# Altium schematic sheet Sheet1.SchDoc
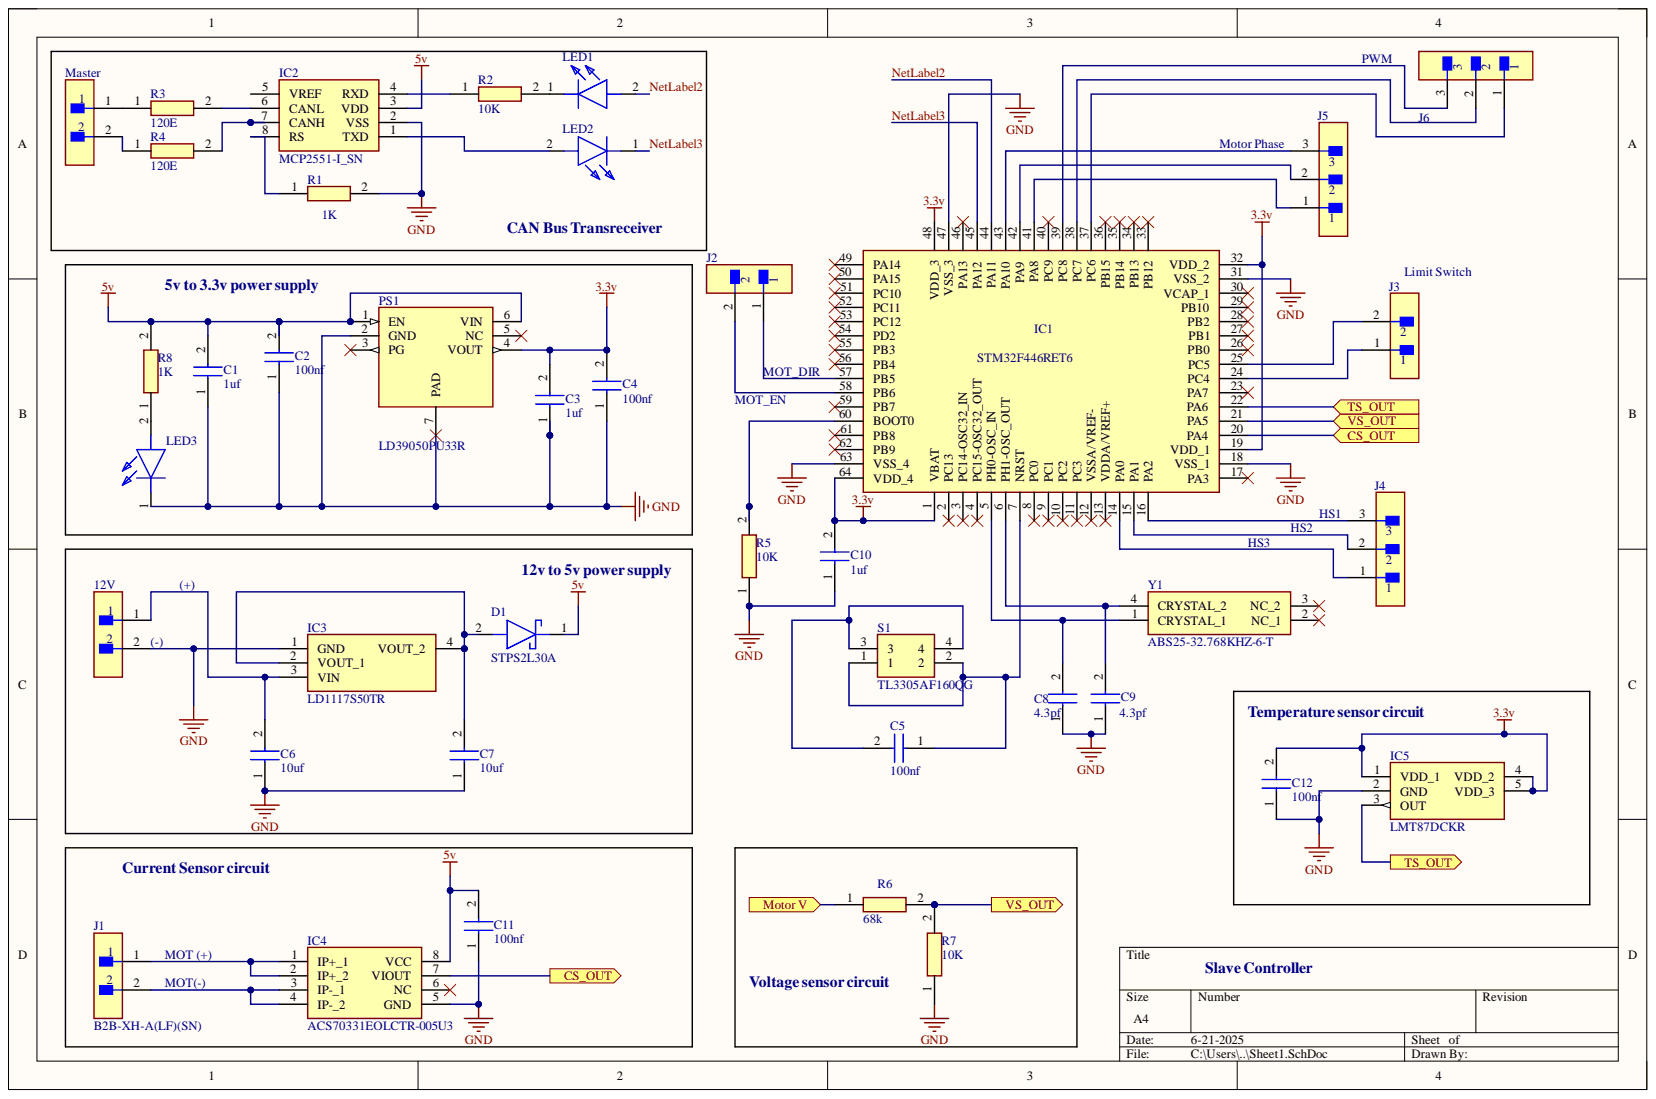
[diagram p0: Sheet1.SchDoc]

## Parts
12V  B2B-XH-A(LF)(SN)  [FP-B2B-XH-A_LF_SN-MFG]
C1  1uf  [CAPC1005X55N]
C2  100nf  [CAPC2012X94N]
C3  1uf  [CAPC1005X55N]
C4  100nf  [CAPC2012X94N]
C5  100nf  [CAPC2012X94N]
C6  10uf  [MBASx31x]
C7  10uf  [MBASx31x]
C8  4.3pf  [GRM033D70J224ME01D]
C9  4.3pf  [GRM033D70J224ME01D]
C10  1uf  [CAPC1005X55N]
C11  100nf  [CAPC2012X94N]
C12  100nf  [CAPC2012X94N]
D1  STPS2L30A  [DIOM5126X265N]
IC1  STM32F446RET6  [QFP50P1200X1200X160-64N]
IC2  MCP2551-I_SN  [SOIC127P600X175-8N]
IC3  LD1117S50TR  [SOT230P700X190-4N]
IC4  ACS70331EOLCTR-005U3  [SOIC127P600X175-8N]  (net tie)
IC5  LMT87DCKR  [SOT65P210X110-5N]
J1  B2B-XH-A(LF)(SN)  [FP-B2B-XH-A_LF_SN-MFG]
J2  B2B-XH-A(LF)(SN)  [FP-B2B-XH-A_LF_SN-MFG]
J3  B2B-XH-A(LF)(SN)  [FP-B2B-XH-A_LF_SN-MFG]
J4  B3B-XH-A(LF)(SN)  [FP-B3B-XH-A_LF_SN-MFG]  (value not drawn)
J5  B3B-XH-A(LF)(SN)  [FP-B3B-XH-A_LF_SN-MFG]  (value not drawn)
J6  B3B-XH-A(LF)(SN)  [FP-B3B-XH-A_LF_SN-MFG]  (value not drawn)
LED1  (no value)  [KSEELP4122P1R258A8J8020R18]
LED2  (no value)  [KSEELP4122P1R258A8J8020R18]
LED3  (no value)  [KSEELP4122P1R258A8J8020R18]
Master  Master  [FP-B2B-XH-A_LF_SN-MFG]
PS1  LD39050PU33R  [SON95P300X300X100-7N-D]
R1  1K  [ERA2AED122X]
R2  10K  [RESC2012X65N]
R3  120E  [RESC1005X40N]
R4  120E  [RESC1005X40N]
R5  10K  [RESC2012X65N]
R6  68k  [RESC1005X37N]
R7  10K  [RESC2012X65N]
R8  1K  [ERA2AED122X]
S1  TL3305AF160QG  [TL3305AF160QG]
Y1  ABS25-32.768KHZ-6-T  [ABS2532768KHZT]

## Ports
CS_OUT, Motor V, TS_OUT, VS_OUT

## Nets
3.3v: C3.2 C4.2 C10.2 C12.2 IC1.1(VBAT) IC1.19(VDD_1) IC1.32(VDD_2) IC1.48(VDD_3) IC1.64(VDD_4) IC5.1(VDD_1) IC5.4(VDD_2) IC5.5(VDD_3) PS1.4(VOUT)
5v: C1.2 C2.2 C11.2 D1.1 IC2.3(VDD) IC4.8(VCC) PS1.1(EN) PS1.6(VIN) R8.2
GND: 12V.2 C1.1 C2.1 C3.1 C4.1 C6.1 C7.1 C8.1 C9.1 C10.1 C11.1 C12.1 IC1.18(VSS_1) IC1.31(VSS_2) IC1.47(VSS_3) IC1.63(VSS_4) IC2.2(VSS) IC3.1(GND) IC4.5(GND) IC5.2(GND) LED3.1 PS1.2(GND) PS1.7(PAD) R1.2 R5.1 R7.1
CS_OUT: IC1.20(PA4) IC4.7(VIOUT) ; port CS_OUT
Motor V: R6.1 ; port Motor V
NetLabel2: IC1.44(PA11) LED1.2
NetLabel3: IC1.45(PA12) LED2.1
TS_OUT: IC1.22(PA6) IC5.3(OUT) ; port TS_OUT
VS_OUT: IC1.21(PA5) R6.2 R7.2 ; port VS_OUT
Net12V_1: 12V.1 C6.2 IC3.3(VIN)
NetC5_1: C5.1 IC1.7(NRST) S1.1 S1.2
NetC5_2: C5.2 S1.3 S1.4
NetC7_2: C7.2 D1.2 IC3.2(VOUT_1) IC3.4(VOUT_2)
NetC8_2: C8.2 IC1.5(PH0-OSC_IN) Y1.1(CRYSTAL_1)
NetC9_2: C9.2 IC1.6(PH1-OSC_OUT) Y1.4(CRYSTAL_2)
NetIC1_14: IC1.14(PA0) J4.1
NetIC1_15: IC1.15(PA1) J4.2
NetIC1_16: IC1.16(PA2) J4.3
NetIC1_24: IC1.24(PC4) J3.1
NetIC1_25: IC1.25(PC5) J3.2
NetIC1_37: IC1.37(PC6) J6.1
NetIC1_38: IC1.38(PC7) J6.2
NetIC1_39: IC1.39(PC8) J6.3
NetIC1_41: IC1.41(PA8) J5.1
NetIC1_42: IC1.42(PA9) J5.2
NetIC1_43: IC1.43(PA10) J5.3
NetIC1_57: IC1.57(PB5) J2.1
NetIC1_58: IC1.58(PB6) J2.2
NetIC1_60: IC1.60(BOOT0) R5.2
NetIC2_1: IC2.1(TXD) LED2.2
NetIC2_4: IC2.4(RXD) R2.1
NetIC2_6: IC2.6(CANL) R3.2
NetIC2_7: IC2.7(CANH) R4.2
NetIC2_8: IC2.8(RS) R1.1
NetIC4_1: IC4.1(IP+_1) IC4.2(IP+_2) J1.1
NetIC4_3: IC4.3(IP-_1) IC4.4(IP-_2) J1.2
NetLED1_1: LED1.1 R2.2
NetLED3_2: LED3.2 R8.1
NetMaster_1: Master.1 R3.1
NetMaster_2: Master.2 R4.1

Not connected: IC1 2(PC13) 3(PC14-OSC32_IN) 4(PC15-OSC32_OUT) 8(PC0) 9(PC1) 10(PC2) 11(PC3) 12(VSSA/VREF-) 13(VDDA/VREF+) 17(PA3) 23(PA7) 26(PB0) 27(PB1) 28(PB2) 29(PB10) 30(VCAP_1) 33(PB12) 34(PB13) 35(PB14) 36(PB15) 40(PC9) 46(PA13) 49(PA14) 50(PA15) 51(PC10) 52(PC11) 53(PC12) 54(PD2) 55(PB3) 56(PB4) 59(PB7) 61(PB8) 62(PB9); IC2 5(VREF); IC4 6(NC); PS1 3(PG) 5(NC); Y1 2(NC_1) 3(NC_2)

## Library data of the parts
12V J1-J3 Master = CMP-2000-05888-3: CONN HEADER VERT 2POS 2.5MM; Connector Type: Male Pin; Contact Resistance: 20mR; Current Rating: 3A; Insulation Resistance: 1GR; Max Voltage Rating (AC): 250V; Number of Contacts: 2; Wire/Cable Gauge: 22AWG; Color: Natural; Component Kind: Standard; Contact Material: Brass; Contact Plating: Tin; Contact Type: Male Pin; Depth: 5.75mm; Fastening Type: Detent; Housing Color: White; Housing Material: Polyamide; Lead Free: Lead Free; Lead Pitch: 2.5mm; Length: 7.4mm; Library Name: Altium Content Vault; Max Current Rating: 3A; Max Operating Temperature: 85°C; Max Voltage Rating (DC): 250V; Min Operating Temperature: -25°C; Mount: Through Hole; Number of Positions: 2; Number of Rows: 1; Orientation: Straight; Packaging: Bulk; Pitch: 2.5mm; REACH SVHC: No SVHC; Series: XH; Termination: Solder; Voltage Rating: 250V; Voltage Rating (AC): 250V
C1 C3 C10 = MAASL105CD7105MFCA01: Capacitor; Manufacturer_Name: TAIYO YUDEN; Component Kind: Standard; Datasheet Link: https://www.mouser.com/datasheet/2/396/TAIYO_YUDEN_04_27_2024_c_mlcc_A_e-3451534.pdf; Height: 0.55mm; Manufacturer_Part_Number: MAASL105CD7105MFCA01; Mouser Part Number: 963-MAASL105CD7105MF; Mouser Price/Stock: https://www.mouser.co.uk/ProductDetail/TAIYO-YUDEN/MAASL105CD7105MFCA01?qs=HFfMDpzxxd1XWA4Yx70b9w%3D%3D
C2 C4 C5 C11 C12 = KAF21BR71H104JM: Capacitor; Manufacturer_Name: Kyocera AVX; Component Kind: Standard; Datasheet Link: https://www.mouser.de/datasheet/2/40/AutoMLCCKAF-3218225.pdf; Height: 0.94mm; Manufacturer_Part_Number: KAF21BR71H104JM; Mouser Part Number: 581-KAF21BR71H104JM; Mouser Price/Stock: https://www.mouser.co.uk/ProductDetail/KYOCERA-AVX/KAF21BR71H104JM?qs=Jm2GQyTW%2FbjLJnSOuM%2FLDw%3D%3D
C6 C7 = MBAST31LAB7106KTNA01: Capacitor; Manufacturer_Name: TAIYO YUDEN; Component Kind: Standard; Datasheet Link: https://ds.yuden.co.jp/TYCOMPAS/or/detail?pn=MBAST31LAB7106KTNA01; Height: 1.6mm; Manufacturer_Part_Number: MBAST31LAB7106KTNA01; Mouser Part Number: 963-BAST31LAB7106KT; Mouser Price/Stock: https://www.mouser.co.uk/ProductDetail/TAIYO-YUDEN/MBAST31LAB7106KTNA01?qs=tlsG%2FOw5FFhDIkirqUaE%252Bg%3D%3D
C8 C9 = GRM0335C2A4R3BA01D: Capacitor; Manufacturer_Name: Murata Electronics; Component Kind: Standard; Datasheet Link: https://search.murata.co.jp/Ceramy/image/img/A01X/G101/ENG/GRM0335C2A4R3BA01-01A.pdf; Height: 0.33mm; Manufacturer_Part_Number: GRM0335C2A4R3BA01D; Mouser Part Number: 81-GRM0335C2A4R3BA1D; Mouser Price/Stock: https://www.mouser.com/Search/Refine.aspx?Keyword=81-GRM0335C2A4R3BA1D
D1 = STPS2L30A: Schottky Diode; Manufacturer_Name: STMicroelectronics; Arrow Part Number: STPS2L30A; Arrow Price/Stock: https://www.arrow.com/en/products/stps2l30a/stmicroelectronics?utm_currency=USD&region=nac; Component Kind: Standard; Datasheet Link: http://www.st.com/web/en/resource/technical/document/datasheet/CD00001633.pdf; Height: 2.65mm; Manufacturer_Part_Number: STPS2L30A; Mouser Part Number: 511-STPS2L30A; Mouser Price/Stock: https://www.mouser.co.uk/ProductDetail/STMicroelectronics/STPS2L30A?qs=yAkVQ3mwCG0OEYUK4%252B3dww%3D%3D
IC1 = STM32F446RET6: Integrated Circuit; Manufacturer_Name: STMicroelectronics; Arrow Part Number: STM32F446RET6; Arrow Price/Stock: https://www.arrow.com/en/products/stm32f446ret6/stmicroelectronics?utm_currency=USD&region=nac; Component Kind: Standard; Datasheet Link: https://datasheet.datasheetarchive.com/originals/distributors/DKDS41/DSANUWW0028583.pdf; Height: 1.6mm; Manufacturer_Part_Number: STM32F446RET6; Mouser Part Number: 511-STM32F446RET6; Mouser Price/Stock: https://www.mouser.co.uk/ProductDetail/STMicroelectronics/STM32F446RET6?qs=Ok1pvOkw6%2FqpQsWf8F4wtQ%3D%3D
IC2 = MCP2551-I_SN: Integrated Circuit; Manufacturer_Name: Microchip; Manufacturer_Part_Number: MCP2551-I/SN; Arrow Part Number: MCP2551-I/SN; Arrow Price/Stock: https://www.arrow.com/en/products/mcp2551-isn/microchip-technology?utm_currency=USD&region=nac; Component Kind: Standard; Datasheet Link: https://datasheet.datasheetarchive.com/originals/distributors/Datasheets-34/DSA-674994.pdf; Height: 1.75mm; Mouser Part Number: 579-MCP2551-I/SN; Mouser Price/Stock: https://www.mouser.co.uk/ProductDetail/Microchip-Technology/MCP2551-I-SN?qs=9y3LFqDLL8L5zFfqqxdOHg%3D%3D
IC3 = LD1117S50TR: Integrated Circuit; Manufacturer_Name: STMicroelectronics; Arrow Part Number: LD1117S50TR; Arrow Price/Stock: https://www.arrow.com/en/products/ld1117s50tr/stmicroelectronics?utm_currency=USD&region=europe; Component Kind: Standard; Datasheet Link: https://datasheet.lcsc.com/szlcsc/STMicroelectronics-LD1117S50TR_C134077.pdf; Height: 1.9mm; Manufacturer_Part_Number: LD1117S50TR; Mouser Part Number: 511-LD1117S50; Mouser Price/Stock: https://www.mouser.co.uk/ProductDetail/STMicroelectronics/LD1117S50TR?qs=eQN2Ig5lfEwsh0kTXN%2FkTg%3D%3D
IC4 = ACS70331EOLCTR-005U3: Integrated Circuit; Manufacturer_Name: Allegro Microsystems; Arrow Part Number: ACS70331EOLCTR-005U3; Arrow Price/Stock: null?region=nac; Datasheet Link: https://www.allegromicro.com/-/media/files/datasheets/acs70331-datasheet.ashx; Height: 1.75mm; Manufacturer_Part_Number: ACS70331EOLCTR-005U3; Mouser Part Number: 250-70331EOLCTR005U3; Mouser Price/Stock: https://www.mouser.co.uk/ProductDetail/Allegro-MicroSystems/ACS70331EOLCTR-005U3?qs=pUKx8fyJudDz9FJ7uQ14Rw%3D%3D
IC5 = LMT87DCKR: Integrated Circuit; Manufacturer_Name: Texas Instruments; Arrow Part Number: LMT87DCKR; Arrow Price/Stock: https://www.arrow.com/en/products/lmt87dckr/texas-instruments?utm_currency=USD&region=nac; Component Kind: Standard; Datasheet Link: http://www.ti.com/lit/gpn/lmt87; Height: 1.1mm; Manufacturer_Part_Number: LMT87DCKR; Mouser Part Number: 595-LMT87DCKR; Mouser Price/Stock: https://www.mouser.co.uk/ProductDetail/Texas-Instruments/LMT87DCKR?qs=TB%2FQ0sBK%2FGewwiyaPQc1Yw%3D%3D
J4-J6 = CMP-17439-000014-3: CONN HEADER VERT 3POS 2.5MM; Contact Resistance: 20mR; Current Rating: 3A; Gender: Male; Insulation Resistance: 1GR; Max Voltage Rating (AC): 250V; Number of Contacts: 3; Wire/Cable Gauge: 22AWG; Color: Natural; Component Kind: Standard; Connector Type: Header; Contact Finish - Mating: TIN; Contact Material: Brass; Contact Plating: Tin; Contact Shape: Square; Contact Type: Male Pin; Depth: 5.75mm; Fastening Type: Detent; Flammability Rating: UL94 V-0; Housing Color: White; Housing Material: Polyamide; Insulation Color: Natural; Insulation Material: Nylon; Lead Free: Lead Free; Lead Pitch: 2.5mm; Length: 9.9mm; Library Name: Altium Content Vault; Max Current Rating: 3A; Max Operating Temperature: 85°C; Max Voltage Rating (DC): 250V; Min Operating Temperature: -25°C; Mount: Through Hole; Number of Positions: 3; Number of Rows: 1; Orientation: Straight; Packaging: Bulk; Pitch: 2.5mm; REACH SVHC: No SVHC; Series: XH; Termination: Solder; Voltage Rating: 250V; Voltage Rating (AC): 250V
LED1-LED3 = KS_EELP41.22-P1R2-58-A8J8-020-R18: LED; Manufacturer_Name: ams OSRAM; Manufacturer_Part_Number: KS EELP41.22-P1R2-58-A8J8-020-R18; Component Kind: Standard; Datasheet Link: https://www.digikey.ph/en/products/detail/ams-osram-usa-inc/KS-EELP41-22-P1R2-58-A8J8-020-R18/24765247; Height: 0.5mm; Mouser Part Number: 720-P412P1R258A8J802; Mouser Price/Stock: https://www.mouser.co.uk/ProductDetail/ams-OSRAM/KS-EELP41.22-P1R2-58-A8J8-020-R18?qs=ZcfC38r4Povp3XTr6Zxf%252Bw%3D%3D
PS1 = LD39050PU33R: Power Supply; Manufacturer_Name: STMicroelectronics; Arrow Part Number: LD39050PU33R; Arrow Price/Stock: https://www.arrow.com/en/products/ld39050pu33r/stmicroelectronics?utm_currency=USD&region=nac; Component Kind: Standard; Datasheet Link: https://componentsearchengine.com/Datasheets/2/LD39050PU33R.pdf; Height: 1mm; Manufacturer_Part_Number: LD39050PU33R; Mouser Part Number: 511-LD39050PU33R; Mouser Price/Stock: https://www.mouser.co.uk/ProductDetail/STMicroelectronics/LD39050PU33R?qs=5HwTSiuA5HCrgw9sKi%2FGcQ%3D%3D
R1 R8 = ERA-2ARC102X: Resistor; Manufacturer_Name: Panasonic; Arrow Part Number: ERA-2ARC102X; Arrow Price/Stock: https://www.arrow.com/en/products/era-2arc102x/panasonic?utm_currency=USD&region=nac; Component Kind: Standard; Datasheet Link: http://industrial.panasonic.com/cdbs/www-data/pdf/RDM0000/AOA0000C307.pdf; Height: 0.4mm; Manufacturer_Part_Number: ERA-2ARC102X; Mouser Part Number: 667-ERA-2ARC102X; Mouser Price/Stock: https://www.mouser.co.uk/ProductDetail/Panasonic/ERA-2ARC102X?qs=kmv%2FWw32SUNfpV2hKlE9eQ%3D%3D
R2 R5 R7 = 4-2176238-9: Resistor; Manufacturer_Name: TE Connectivity; Component Kind: Standard; Datasheet Link: https://www.te.com/commerce/DocumentDelivery/DDEController?Action=srchrtrv&DocNm=1773200-1&DocType=Data%20Sheet&DocLang=English&DocFormat=pdf&PartCntxt=4-2176238-9; Height: 0.65mm; Manufacturer_Part_Number: 4-2176238-9
R3 R4 = RG1005P-121-B-T5: Resistor; Manufacturer_Name: Susumu; Component Kind: Standard; Datasheet Link: http://www.susumu.co.jp/common/pdf/n_catalog_partition01_en.pdf; Height: 0.4mm; Manufacturer_Part_Number: RG1005P-121-B-T5; Mouser Part Number: 754-RG1005P-121-BT5; Mouser Price/Stock: https://www.mouser.com/Search/Refine.aspx?Keyword=754-RG1005P-121-BT5
R6 = MCS04020D6802BE000: Resistor; Manufacturer_Name: Vishay; Component Kind: Standard; Datasheet Link: http://www.vishay.com/docs/28705/mcx0x0xpro.pdf; Height: 0.37mm; Manufacturer_Part_Number: MCS04020D6802BE000
S1 = TL3305AF160QG: Switch; Manufacturer_Name: E-Switch; Component Kind: Standard; Datasheet Link: https://configured-product-images.s3.amazonaws.com/2D/specs/TL3305AF160QG.pdf; Manufacturer_Part_Number: TL3305AF160QG; Mouser Part Number: 612-TL3305AF160QG; Mouser Price/Stock: https://www.mouser.co.uk/ProductDetail/E-Switch/TL3305AF160QG?qs=UrFqKgNWc7RTylqwItwDfw%3D%3D
Y1 = ABS25-32.768KHZ-6-T: Crystal or Oscillator; Manufacturer_Name: ABRACON; Arrow Part Number: ABS25-32.768KHZ-6-T; Arrow Price/Stock: https://www.arrow.com/en/products/abs25-32.768khz-6-t/abracon?utm_currency=USD&region=nac; Component Kind: Standard; Datasheet Link: https://abracon.com/Resonators/abs25.pdf; Height: 2mm; Manufacturer_Part_Number: ABS25-32.768KHZ-6-T; Mouser Part Number: 815-ABS25-32.768K6-T; Mouser Price/Stock: https://www.mouser.co.uk/ProductDetail/ABRACON/ABS25-32.768KHZ-6-T?qs=wl%2FhatdkTudHMJeSjMoOzg%3D%3D
